# Altium schematic sheet Motion_Control_Inputs.SchDoc
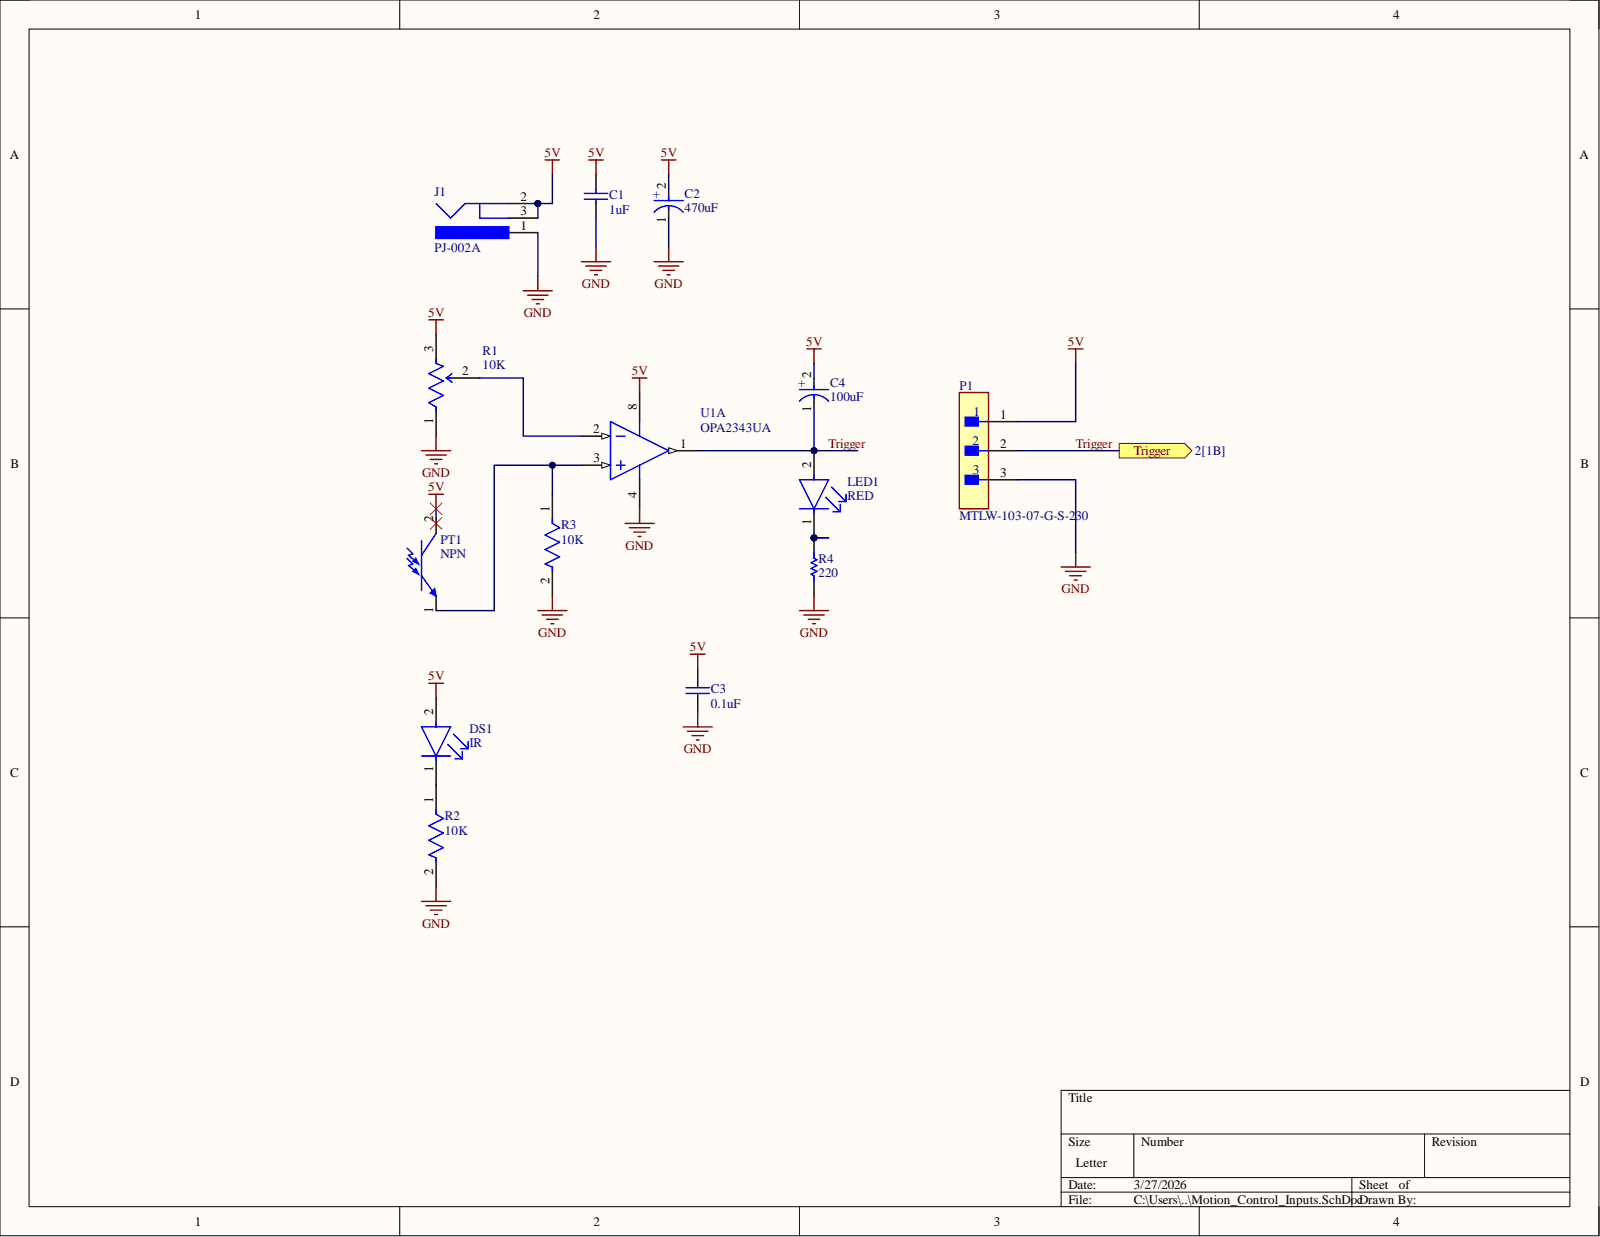
[diagram p0: Motion_Control_Inputs.SchDoc]

## Parts
C1  1uF  [CAPC2013X100X50ML20T25]
C2  470uF  [FP-TR3E-MFG]
C3  0.1uF  [CAPC2013X145X50ML20T20]
C4  100uF  [FP-TR3B-MFG]
DS1  IR  Reverse Voltage: 5V  [FP-SFH_4554-MFG]
J1  PJ-002A  [CUI-PJ-002A_V]
LED1  RED  [FP-HLMP-4700-MFG]
P1  MTLW-103-07-G-S-230  [FP-MTLW-103-07-G-S-230-MFG]
PT1  NPN  [PhotoTr_PT334-6C]
R1  10K  [FP-TS53YL-MFG]
R2  10K  [FP-RP73P-2A-IPC_B]
R3  10K  [FP-RP73P-2A-IPC_B]
R4  220  [RESC2013X60X30NL20T20]
U1  OPA2343UA  [D0008A_N]  (part A)

## Ports
Trigger

## Nets
5V: C1.2 C2.2 C3.2 C4.2 DS1.2 J1.2 J1.3 P1.1 PT1.2 R1.3 U1.8
GND: C1.1 C2.1 C3.1 J1.1 P1.3 R1.1 R2.2 R3.2 R4.2 U1.4
Trigger: C4.1 LED1.2 P1.2 U1.1 ; port Trigger
NetDS1_1: DS1.1 R2.1
NetLED1_1: LED1.1 R4.1
NetPT1_1: PT1.1 R3.1 U1.3
NetR1_2: R1.2 U1.2

## Library data of the parts
C1 = CAP 1uF 10V 0805: Manufacturer: Kemet; Part Number: C0805X105K8RACTU; Component Kind: Standard; Library Name: MDL.SchLib
C2 = CAP Pol 470uF 10V SMD: TR3E477K010C0100 Cap Tant Solid 470uF 10V E CASE 10% (7.3 X 4.3 X 4mm) Inward L SMD 7343-43 0.1 Ohm 125C T/R; NexarPartId: 11565183; Capacitance: 470µF; Dielectric Material: Tantalum; Dissipation Factor: 15%; ESR (Equivalent Series Resistance): 100mΩ; Leakage Current: 47µA; Polarity: Polar; Ripple Current: 1.28A; Case Code (Imperial): 2917; Case Code (Metric): 7343; Case/Package: Molded; Component Kind: Standard; Depth: 4.3mm; Height: 4mm; Length: 7.3mm; Max Operating Temperature: 125°C; Min Operating Temperature: -55°C; Mount: Surface Mount; Number of Pins: 2; Packaging: Cut Tape; Radiation Hardening: No; REACH SVHC: Unknown; RoHS: Compliant; Schedule B: 8532210050, 8532210050|8532210050|8532210050|8532210050|8532210050; Termination: SMD/SMT; Tolerance: 10%; Voltage: 10V; Voltage Rating: 10V; Voltage Rating (DC): 10V; Width: 4.3mm; Library Name: MDL.SchLib
C3 = CAP 0.1uF 10V 0805: Manufacturer: Vitramon; Part Number: VJ0805Y104MXQCW1BC; Component Kind: Standard; Library Name: MDL.SchLib
C4 = CAP Pol 100uF 10V SMT: TR3B107M010C1400 Cap Tant Solid 100uF 10V B CASE 20% (3.5 X 2.8 X 1.9mm) Inward L SMD 3528-21 1.4 Ohm 125C T/R; NexarPartId: 44433230; Capacitance: 100µF; Dissipation Factor: 25%; ESR (Equivalent Series Resistance): 1.4Ω; Leakage Current: 10µA; Polarity: Polar; Ripple Current: 250mA; Case Code (Imperial): 1411; Case Code (Metric): 3528; Case/Package: 1411; Component Kind: Standard; Depth: 2.8mm; Features: General Purpose; Height: 2.1mm; Height - Seated (Max): 2.1082mm; Lead Free: Lead Free; Length: 3.5mm; Max Operating Temperature: 125°C; Min Operating Temperature: -55°C; Mount: Surface Mount; Number of Pins: 2; Packaging: Cut Tape; REACH SVHC: Unknown; RoHS: Compliant; Schedule B: 8532210050, 8532210050|8532210050, 8532210050|8532210050|8532210050, 8532210050|8532210050|8532210050|8532210050; Termination: SMD/SMT; Tolerance: 20%; Voltage Rating: 10V; Voltage Rating (DC): 10V; Width: 2.794mm; Library Name: MDL.SchLib
DS1 = IR Emitter 5mm: EMITTER IR 850NM; Manufacturer: Osram Opto; Colour: Infrared; Forward Current: 100mA; Forward Voltage: 1.7V; Led Orientation: Straight; Peak Emmision Wavelength: 860nm; Power Dissipation: 200mW; Viewing Angle: 20°; Automotive: No; Category: Diode; Component Kind: Standard; ComponentLink2Description: Datasheet; ComponentLink2URL: https://forum.upverter.com/uploads/short-url/9WLvpCRBrQtL1CWwnUt39ojzKI8.pdf; Device Class L1: Optoelectronics; Device Class L2: LEDs; Device Class L3: unset; Digikey Description: EMITTER IR 850NM; Lead Free: Yes; Manufacturer Part Number: SFH 4554; Mouser Description: Infrared Emitter 850nm 550mW/sr Circular Top Mount 2-Pin T-1 3/4; Octopart: https://octopart.com/sfh+4554-osram+opto-55819455; Package: SFH_4554; RoHS: TRUE; Temperature: -40°C to +100°C; Temperature Range High: +100°C; Temperature Range Low: -40°C; Library Name: MDL.SchLib
J1 = Connector DC Power Jack 2mm: Through Hole Right Angle DC Power Jack, 2.5 A, 2 mm Center Pin, 3 Position, -25 to 85 degC, RoHS, Bulk; Manufacturer: CUIInc.; Brand: CUI; Current Rating: 2.5A; Inside Contact Diameter: 2mm; Insulation Resistance: 50MOhms; Outside Contact Diameter: 6.5mm; Voltage Rating: 24V; Component Kind: Standard; Connector Type: DCPowerJack; Contact Gender: Male; Contact Material: Copper; Contact Plating: Nickel; Datasheet URL: http://www.cui.com/product/resource/pj-002a.pdf; Datasheet Version: Rev. 1.03, 04/2016; Factory Pack Quantity: 500; Flammability Rating: UL94V-0; Housing Material: PolybutyleneTerephthalate(PBT); Manufacturer URL: http://www.cui.com/; Mounting Angle: Right; Mounting Style: ThroughHole; Mounting Technology: ThroughHole; Operating Temperature Range: -25Cto+85C; Package Description: 3-Pin Through Hole Device, Body 14.4 x 9 mm, Height 11 mm; Package Reference: PJ-002A; Product: Jacks; Product Category: DCPowerConnectors; RoHS: True; Termination Style: ThroughHole; Library Name: MDL.SchLib
LED1 = LED 5mm Red: LED RED DIFFUSED T-1 3/4 T/H; Manufacturer: Broadcom; Forward Current: 2mA; Forward Voltage: 1.7V; Led Orientation: Straight; Luminous Intensity: 2.3mcd; Peak Emmision Wavelength: 635nm; Power Dissipation: 14mW; Reverse Voltage: 5V; Viewing Angle: 50°; Wavelength: 626nm; Automotive: No; Category: Diode; Colour: Red; Component Kind: Standard; ComponentLink2Description: Datasheet; ComponentLink2URL: https://docs.broadcom.com/docs/AV02-1557EN; Device Class L1: Optoelectronics; Device Class L2: LEDs; Device Class L3: unset; Digikey Description: LED RED DIFFUSED T-1 3/4 T/H; Lead Free: Yes; Manufacturer Part Number: HLMP-4700; Mouser Description: LED; Red; 2.3mcd; 0.24In.Dia.; T-1-3/4; 500mA; 1.7V; 635nm; 50deg; 5V; 24mW; -55degC;; Octopart: https://octopart.com/hlmp-4700-broadcom-71130526; Package: HLMP-4700; RoHS: TRUE; Temperature: -40°C to +100°C; Temperature Range High: +100°C; Temperature Range Low: -40°C; Library Name: MDL.SchLib
P1 = Header 3POS 2.54mm TH Single Row: CONN HEADER VERT 3POS 2.54MM; Gender: Male; Max Current Rating: 3A; Number of Contacts: 3; Component Kind: Standard; Contact Material: Bronze; Contact Plating: Gold; Depth: 2.48mm; Housing Color: Black; Housing Material: Polymer; Length: 7.62mm; Max Operating Temperature: 125°C; Min Operating Temperature: -55°C; Number of Rows: 1; Orientation: Straight; Packaging: Bulk; Pitch: 2.54mm; Radiation Hardening: No; RoHS Compliant: Yes; Termination: Solder; Library Name: MDL.SchLib
PT1 = Phototransistor 5mm: Phototransistor Chip Silicon 940nm 2-Pin T-1 Bag / 5mm Phototransistor, T-1 3/4; Manufacturer: Everlight; Manufacturer Part Number: PT334-6B; Component Kind: Standard; Library Name: MDL.SchLib
R1 = Res 10K Trimmer: TRIMMER 10K OHM 0.25W GW TOP ADJ; Max Power Dissipation: 250mW; Number of Turns: 1; Resistance: 10kR; Resistance Tolerance: 20%; Temperature Coefficient: 100ppm/°C; Component Kind: Standard; Depth: 6.4mm; Height: 2.7mm; Lead Free: Lead Free; Length: 5mm; Max Operating Temperature: 125°C; Military Standard: Not; Min Operating Temperature: -55°C; Mount: Surface Mount; Package Quantity: 1; Packaging: Tape and Reel; Power Rating: 250mW; Radiation Hardening: No; Resistor Type: Cermet; RoHS Compliant: Yes; Series: TS53Y; Taper: Linear; Technology: CERMET; Termination: Gull Wing; Tolerance: 20%; Width: 5mm; Library Name: MDL.SchLib
R2 R3 = Res 10K 0.25W SMD: RP73P 0805 10KΩ 0.25W 25ppm/°C 0.10% 150V; Manufacturer: TE Connectivity; Manufacturer Part Number: RP73PF2A10KBTDF; Tolerance: 0.001; Automotive: No; Category: Res; Component Kind: Standard; ComponentLink2Description: Datasheet; ComponentLink2URL: https://www.te.com/commerce/DocumentDelivery/DDEController?Action=srchrtrv&DocNm=1773272&DocType=DS&DocLang=English; Composition: Thin Film; Device Class L1: Passive Components; Device Class L2: Resistors; Device Class L3: Chip SMD Resistors; Height: 0.65mm; Ipc Land Pattern Name: RESC200125X55; Lead Free: Yes; Octopart: https://octopart.com/2176093-5-te+connectivity-46441071; Package: RP73P-2A; Power Rating: 0.25W; Resistance: 10KΩ; RoHS: TRUE; Series: RP73P; Temperature: -55°C to +155°C; Temperature Coefficient: 25ppm/°C; Temperature Range High: +155°C; Temperature Range Low: -55°C; Value: 10KΩ; Voltage Rating: 150V; Library Name: MDL.SchLib
R4 = Res 220 Ohm 0.25W SMD: Manufacturer: KOA Speer; Part Number: SG73S2ATTD2200F; Component Kind: Standard; Library Name: MDL.SchLib
U1 = IC OpAmp General Purpose Dual Circuit: Single-Supply, Rail-to-Rail Operational Amplifier MicroAmplifier(TM) Series, 2.7 to 5.5 V, -40 to 85 degC, 8-pin SOIC (D8), Green (RoHS & no Sb/Br); Manufacturer: Texas Instruments; CMRR(Min)(dB): 74; GBW(Typ)(MHz): 5.5; IIB(Max)(pA): 10; Iq per channel(Max)(mA): 1.25; Number of Channels: 2; Offset Drift(Typ)(uV/C): 3; Packing: TUBE; Rating: Catalog; Slew Rate(Typ)(V/us): 6; Sub Family: High Output Current Amplifier (>=50mA), Low Input Bias Current Amp (<=10pA), Low Supply Voltage Amplifier (<=2.7V), Precision Amplifier; Total Supply Voltage(Min)(+5V is 5, +/-5V is 10): 2.7; Vio (25C)(Max)(mV): 8; Code_JEDEC: MS-012; Component Kind: Standard; ComponentLink1Description: Manufacturer URL; ComponentLink1URL: http://www.ti.com/; ComponentLink2Description: Datasheet; ComponentLink2URL: http://www.ti.com/lit/ds/symlink/opa2343.pdf; ComponentLink3Description: Package Specification; ComponentLink3URL: http://www.ti.com/litv/pdf/msoi002j; DatasheetVersion: SBOS090A; Eco-Plan: Green (RoHS & no Sb/Br); Mounting Technology: Surface Mount; PackageDescription: 8-Pin Plastic Small Outline, Body 4.9 x 3.9 mm, Pitch 1.27 mm; PackageReference: D0008A; PackageVersion: 4040047-3/M, 06/2011; PartNumber: OPA2343UA; Total Supply Voltage(Max)(+5V is 5, +/-5V is 10): 5.5; Library Name: MDL.SchLib
